# Altium schematic sheet Schematic.SchDoc
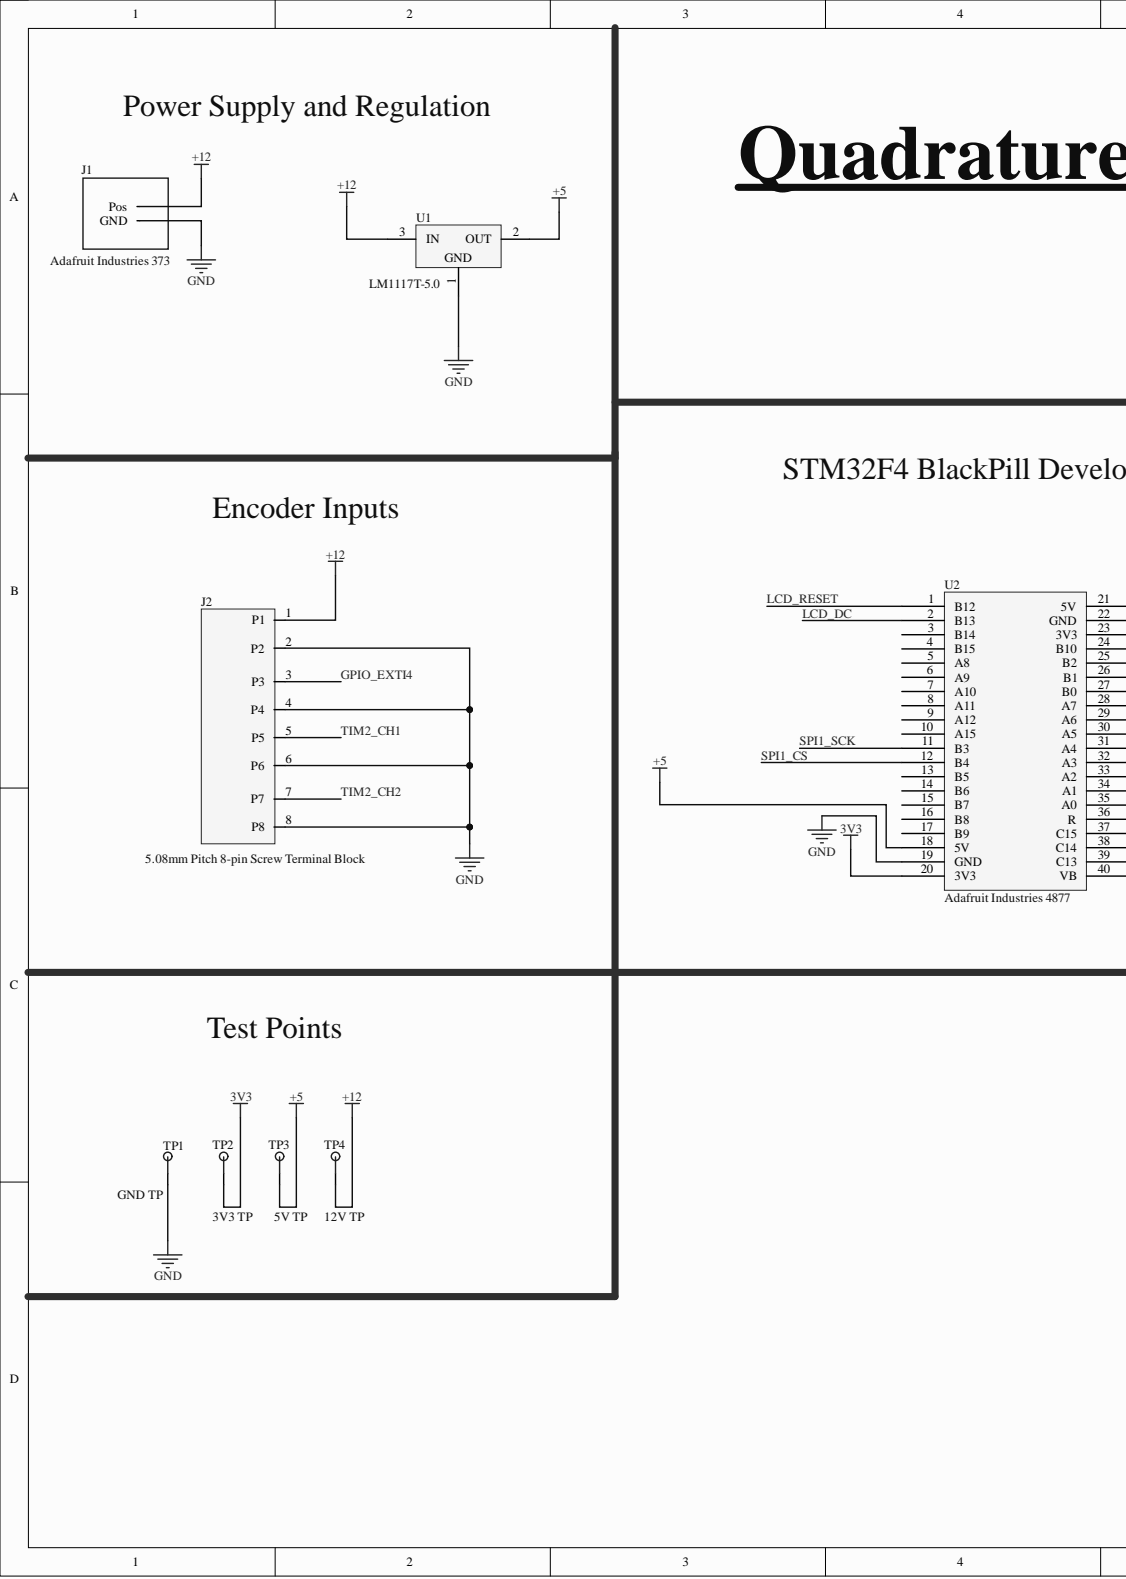
[diagram p0: Schematic.SchDoc, left]
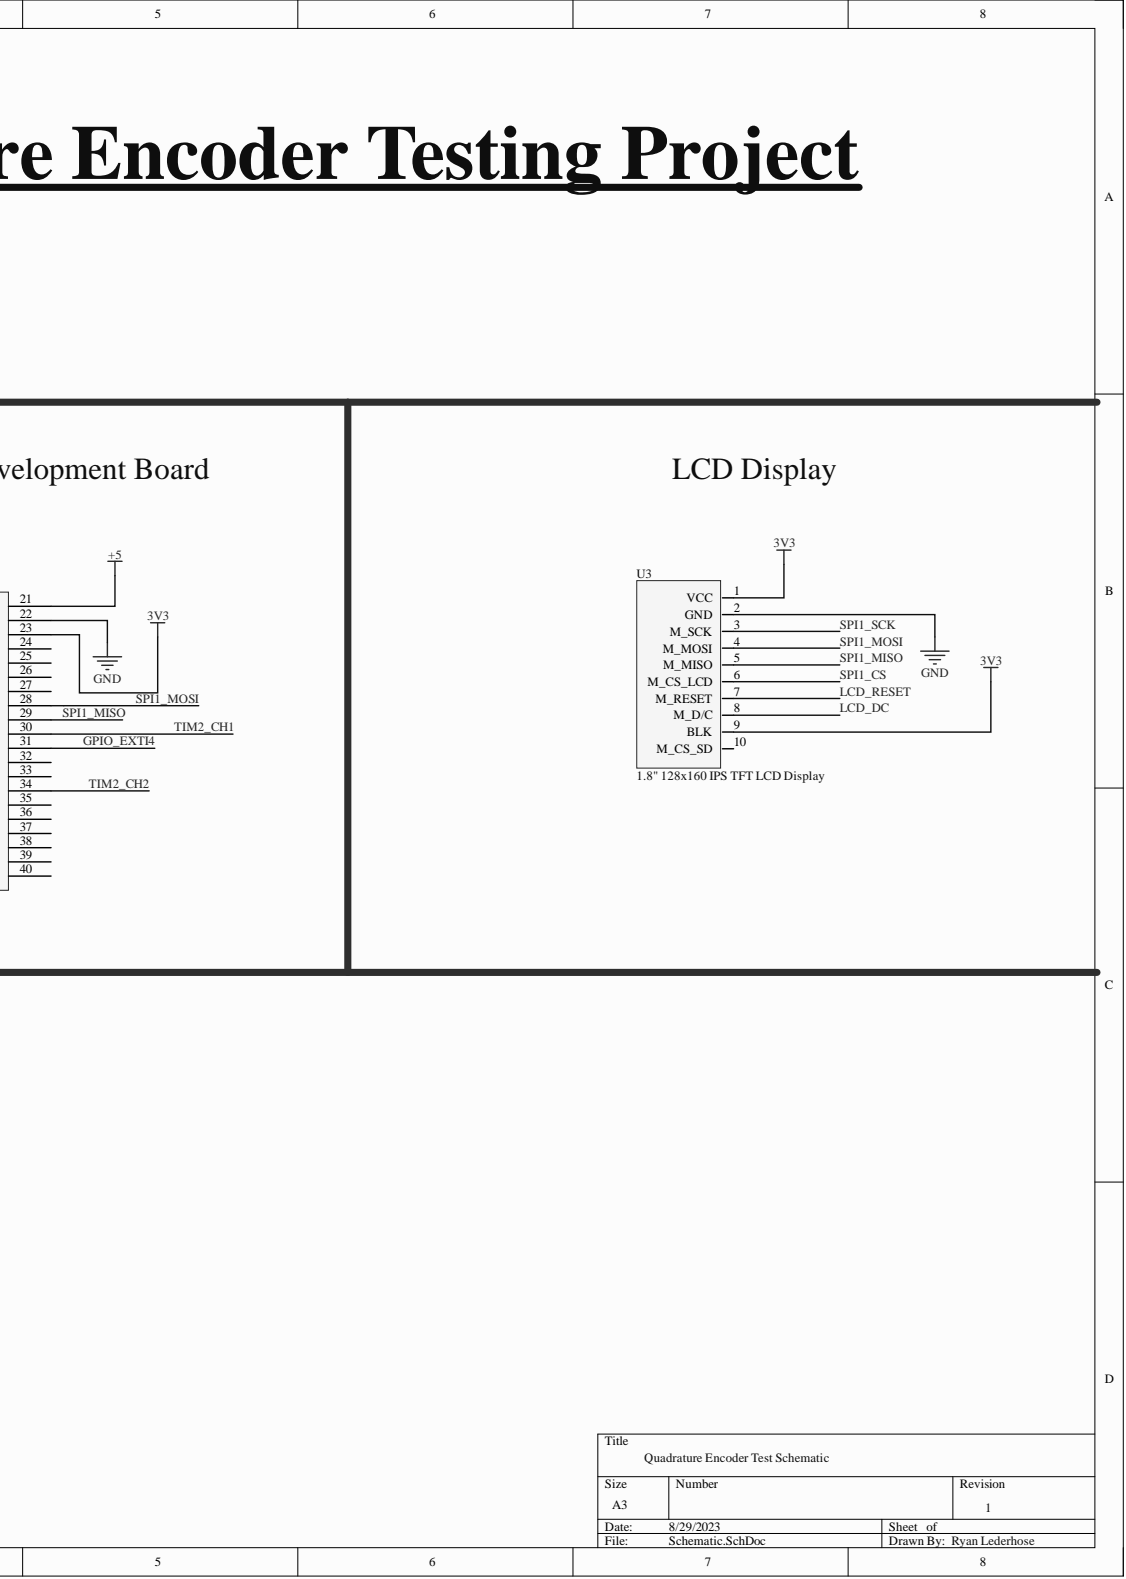
[diagram p1: Schematic.SchDoc, right]

## Parts
J1  Adafruit Industries 373  [Adafruit Industries 373-Footprint-1]
J2  5.08mm Pitch 8-pin Screw Terminal Block  [2.54mm Pitch 4-Pin Screw Terminal Block-Footprint-1]
TP1  GND TP  [New footprint 1]
TP2  3V3 TP  [New footprint 1]
TP3  5V TP  [New footprint 1]
TP4  12V TP  [New footprint 1]
U1  LM1117T-5.0  [T03B]
U2  Adafruit Industries 4877  Manufacturer Part Number: 4877  [Adafruit Industries 4877-Footprint-1]
U3  1.8" 128x160 IPS TFT LCD Display  [1.8" 128x160 IPS TFT LCD Display-Footprint-1]

## Nets
3V3: TP2.1 U2.20(3V3) U2.23(3V3) U3.1(VCC) U3.9(BLK)
+5: TP3.1 U1.2 U2.18(5V) U2.21(5V)
+12: J1.1 J2.1(P1) TP4.1 U1.3
GND: J1.2 J2.2(P2) J2.4(P4) J2.6(P6) J2.8(P8) TP1.1 U1.1 U2.19(GND) U2.22(GND) U3.2(GND)
GPIO_EXTI4: J2.3(P3) U2.31(A4)
LCD_DC: U2.2(B13) U3.8(M_D/C)
LCD_RESET: U2.1(B12) U3.7(M_RESET)
SPI1_CS: U2.12(B4) U3.6(M_CS_LCD)
SPI1_MISO: U2.29(A6) U3.5(M_MISO)
SPI1_MOSI: U2.28(A7) U3.4(M_MOSI)
SPI1_SCK: U2.11(B3) U3.3(M_SCK)
TIM2_CH1: J2.5(P5) U2.30(A5)
TIM2_CH2: J2.7(P7) U2.34(A1)

Not connected: U2 3(B14) 4(B15) 5(A8) 6(A9) 7(A10) 8(A11) 9(A12) 10(A15) 13(B5) 14(B6) 15(B7) 16(B8) 17(B9) 24(B10) 25(B2) 26(B1) 27(B0) 32(A3) 33(A2) 35(A0) 36(R) 37(C15) 38(C14) 39(C13) 40(VB); U3 10(M_CS_SD)

## Library data of the parts
J1 = CMP-1b81d37c0862c446-1: Breadboard-Friendly 2.1Mm Dc Barrel Jack Rohs Compliant: Yes; Manufacturer Part Number: 373; Component Kind: Standard; Library Name: My Personal Space; Octopart Url: https://octopart.com/373-adafruit+industries-32978565; OctopartID: 1b81d37c0862c446; RoHS: Compliant
J2 = CMP-b9n9rkq51cst34j0wbut-2: 2.54mm Pitch 4-Pin Screw Terminal Block; Component Kind: Standard; CustomComponentID: b9n9rkq51cst34j0wbut; Library Name: My Personal Space
TP1-TP4 = CMP-u66ki4pz2pe7w02ae6hg-1: Test Point for Power; Component Kind: Standard; CustomComponentID: u66ki4pz2pe7w02ae6hg; Library Name: My Personal Space
U1 = CMP-0062-02495-3: 800mA Low-Dropout Linear Regulator, 3-pin TO-220; Dropout Voltage: 1.2 V; Number of Outputs: 1; Output Current: 0.8 A; Output Voltage: 5.0 V; Quiescent Current: 0.005 A; Case/Package: TO-220; Component Kind: Standard; Library Name: My Personal Space; Lifecycle Status: Active; Manufacturer Part Number: LM1117T-5.0; Mounting Style: Through Hole; Number of Pins: 3; Octopart Url: https://octopart.com/lm1117t-5.0-texas+instruments-24843812; OctopartID: 454f8d3e760d9a88; Packaging: Rail; REACH SVHC Compliance: No SVHC; RoHS: Compliant
U2 = CMP-92875d76f1274d40-16: STM32F411 "BlackPill" Development Board; Component Kind: Standard; Library Name: My Personal Space; Octopart Url: https://octopart.com/4877-adafruit+industries-117130950; OctopartID: 92875d76f1274d40
U3 = CMP-q8x469s29a0kudm8ejqp-4: Component Kind: Standard; CustomComponentID: q8x469s29a0kudm8ejqp; Description: 1.8" 128x160 IPS TFT LCD Display; Library Name: My Personal Space
